# Altium schematic sheet 200_Power.SchDoc
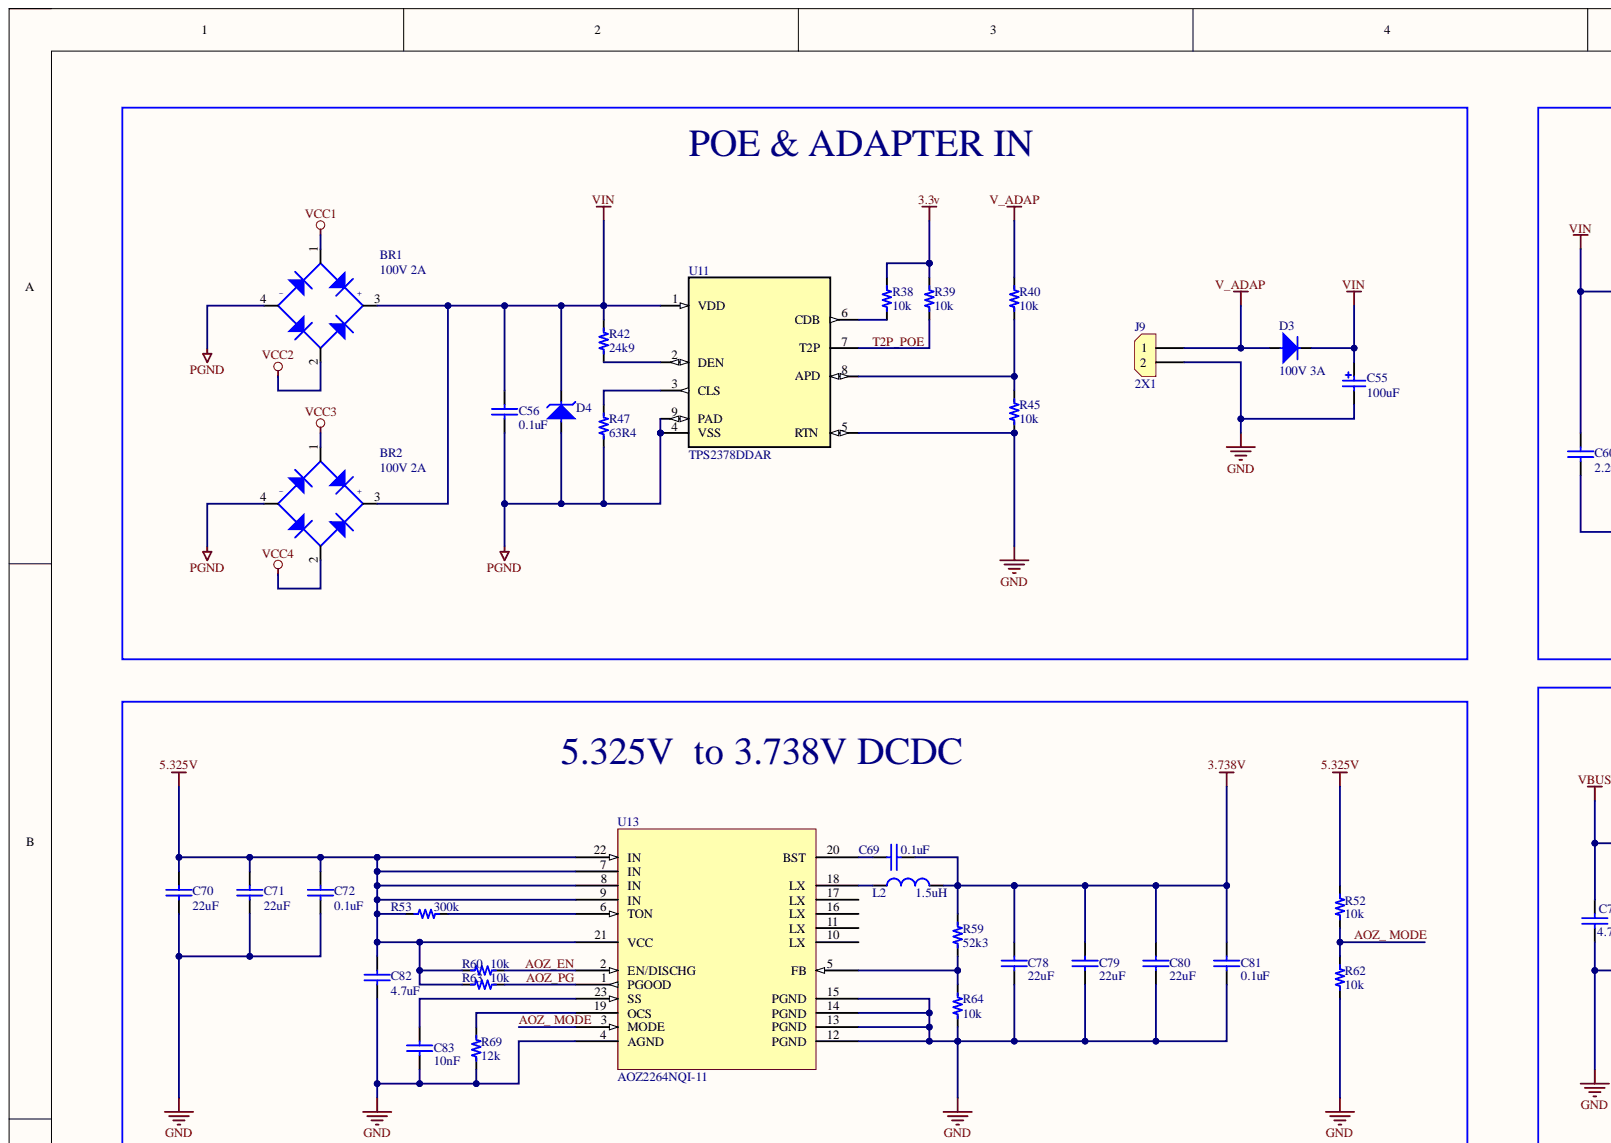
[diagram p0: 200_Power.SchDoc, top left]
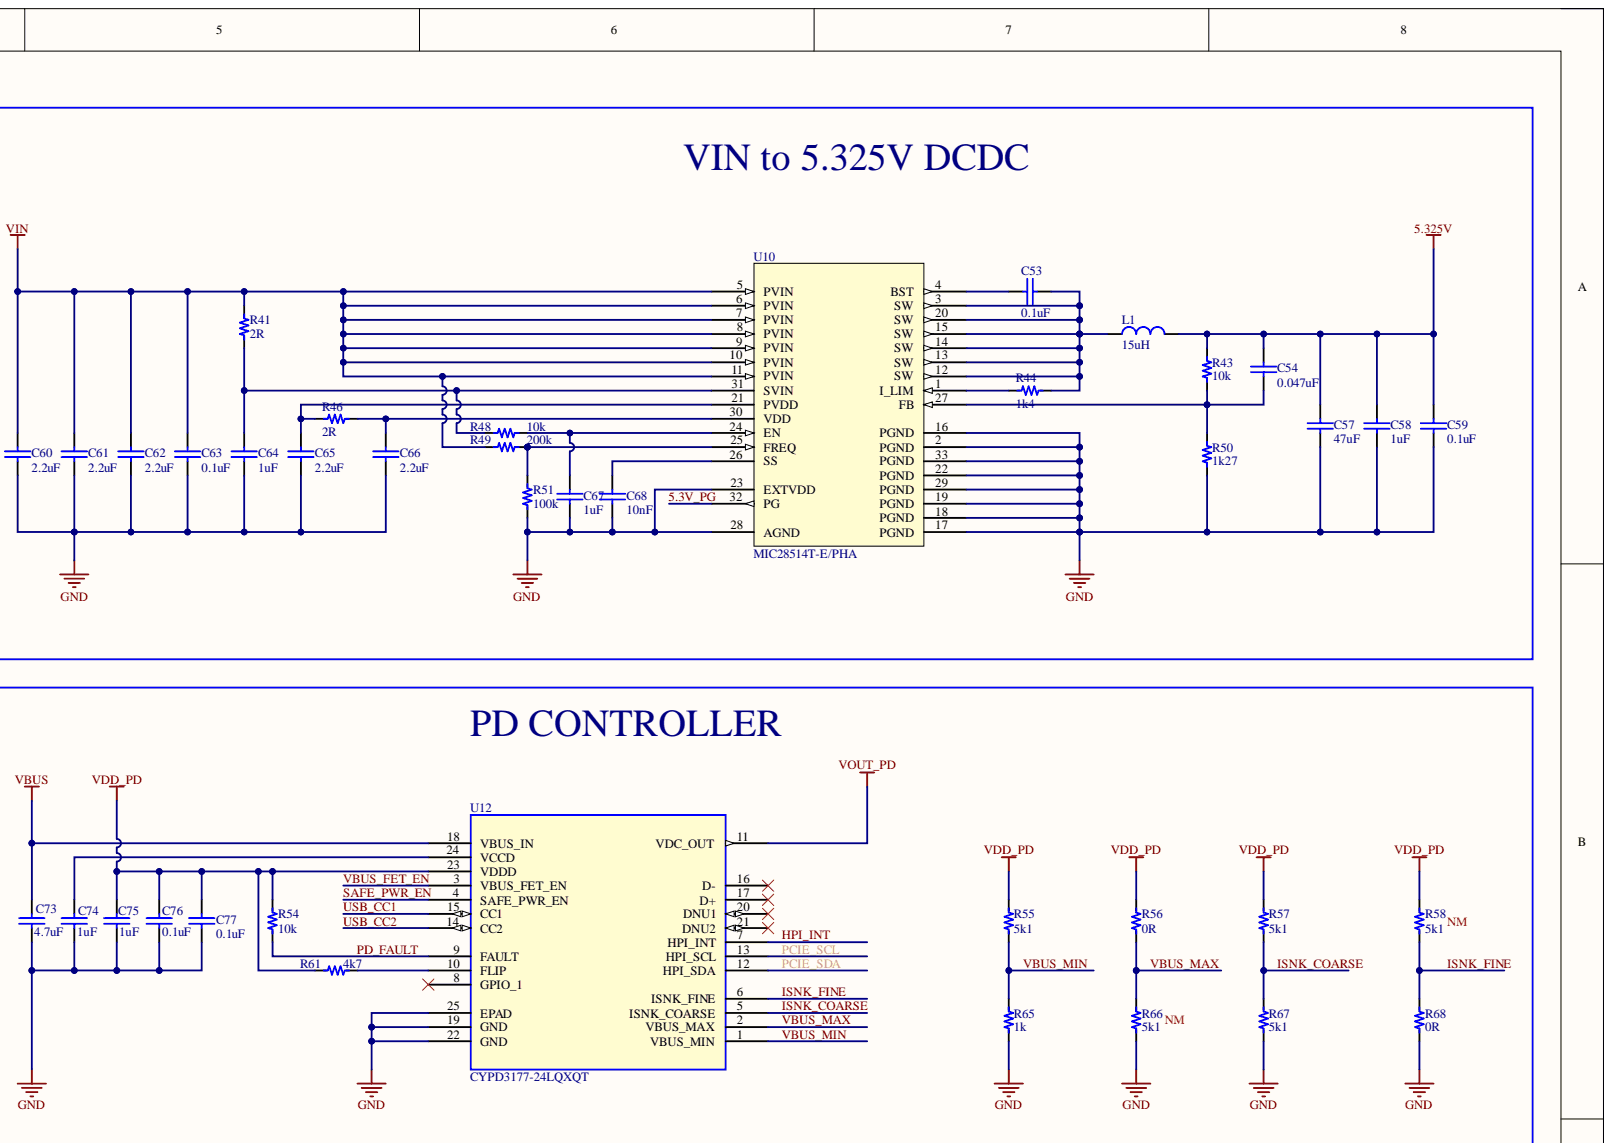
[diagram p1: 200_Power.SchDoc, top right]
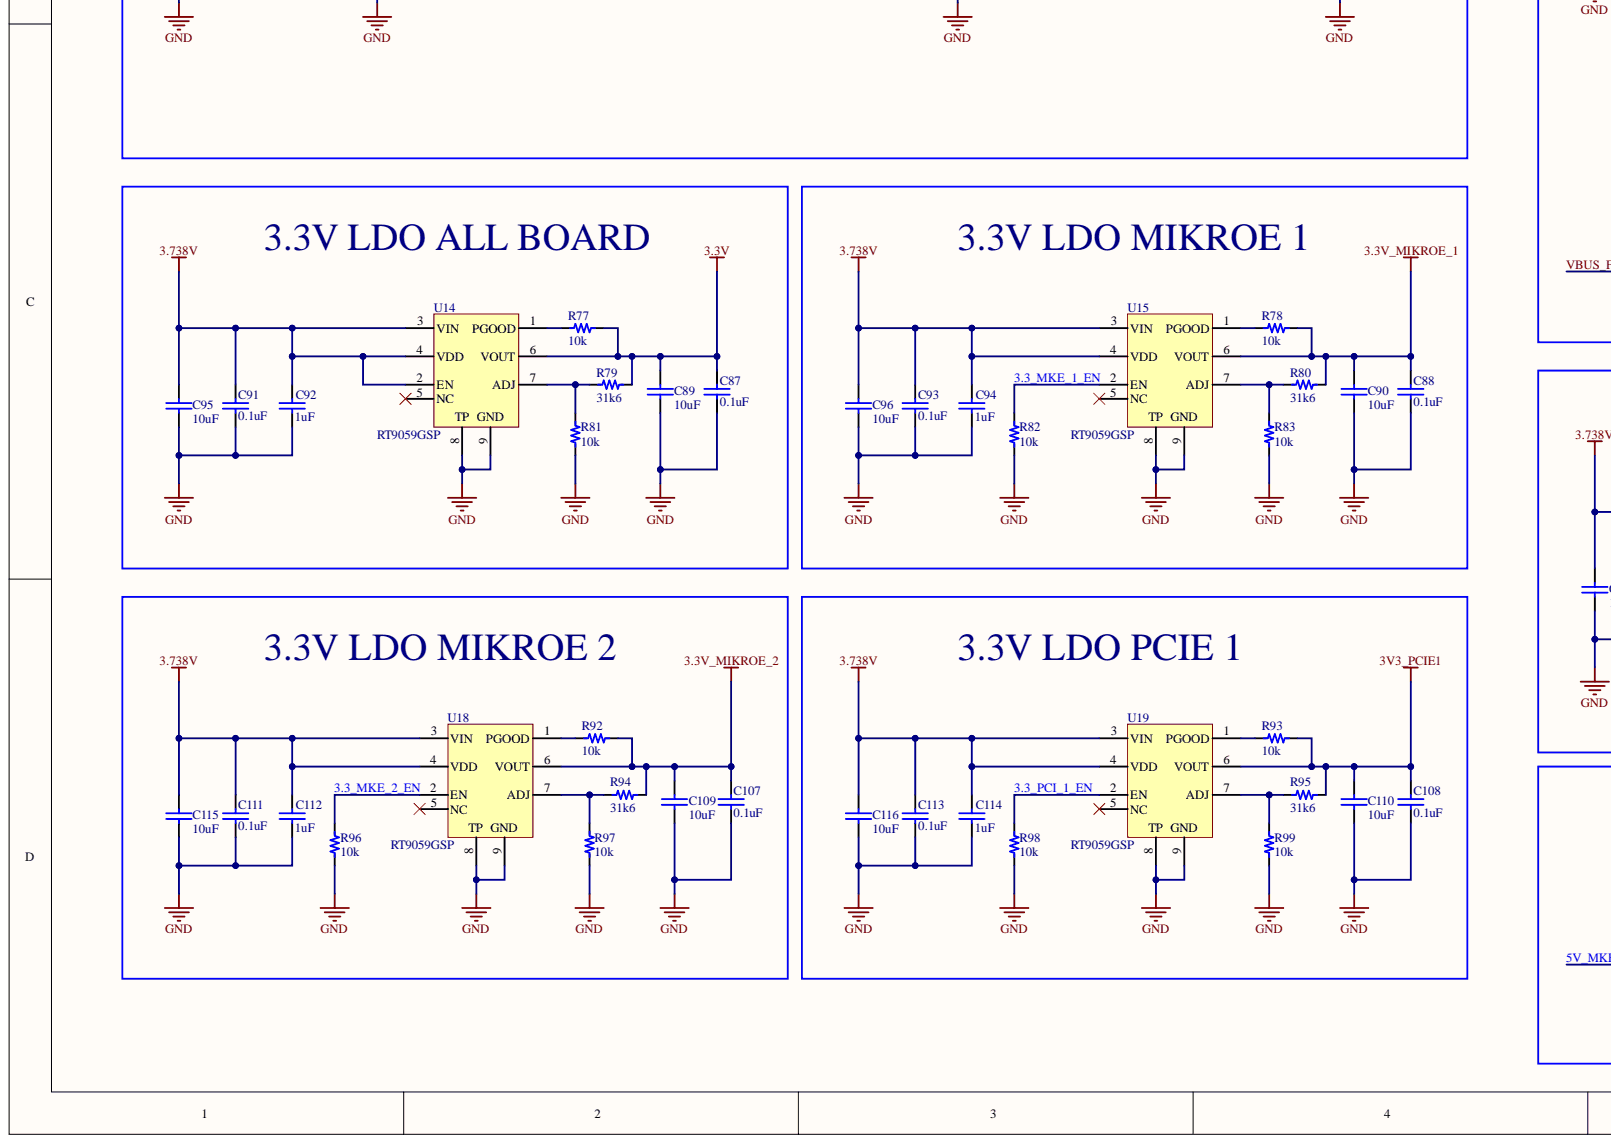
[diagram p2: 200_Power.SchDoc, bottom left]
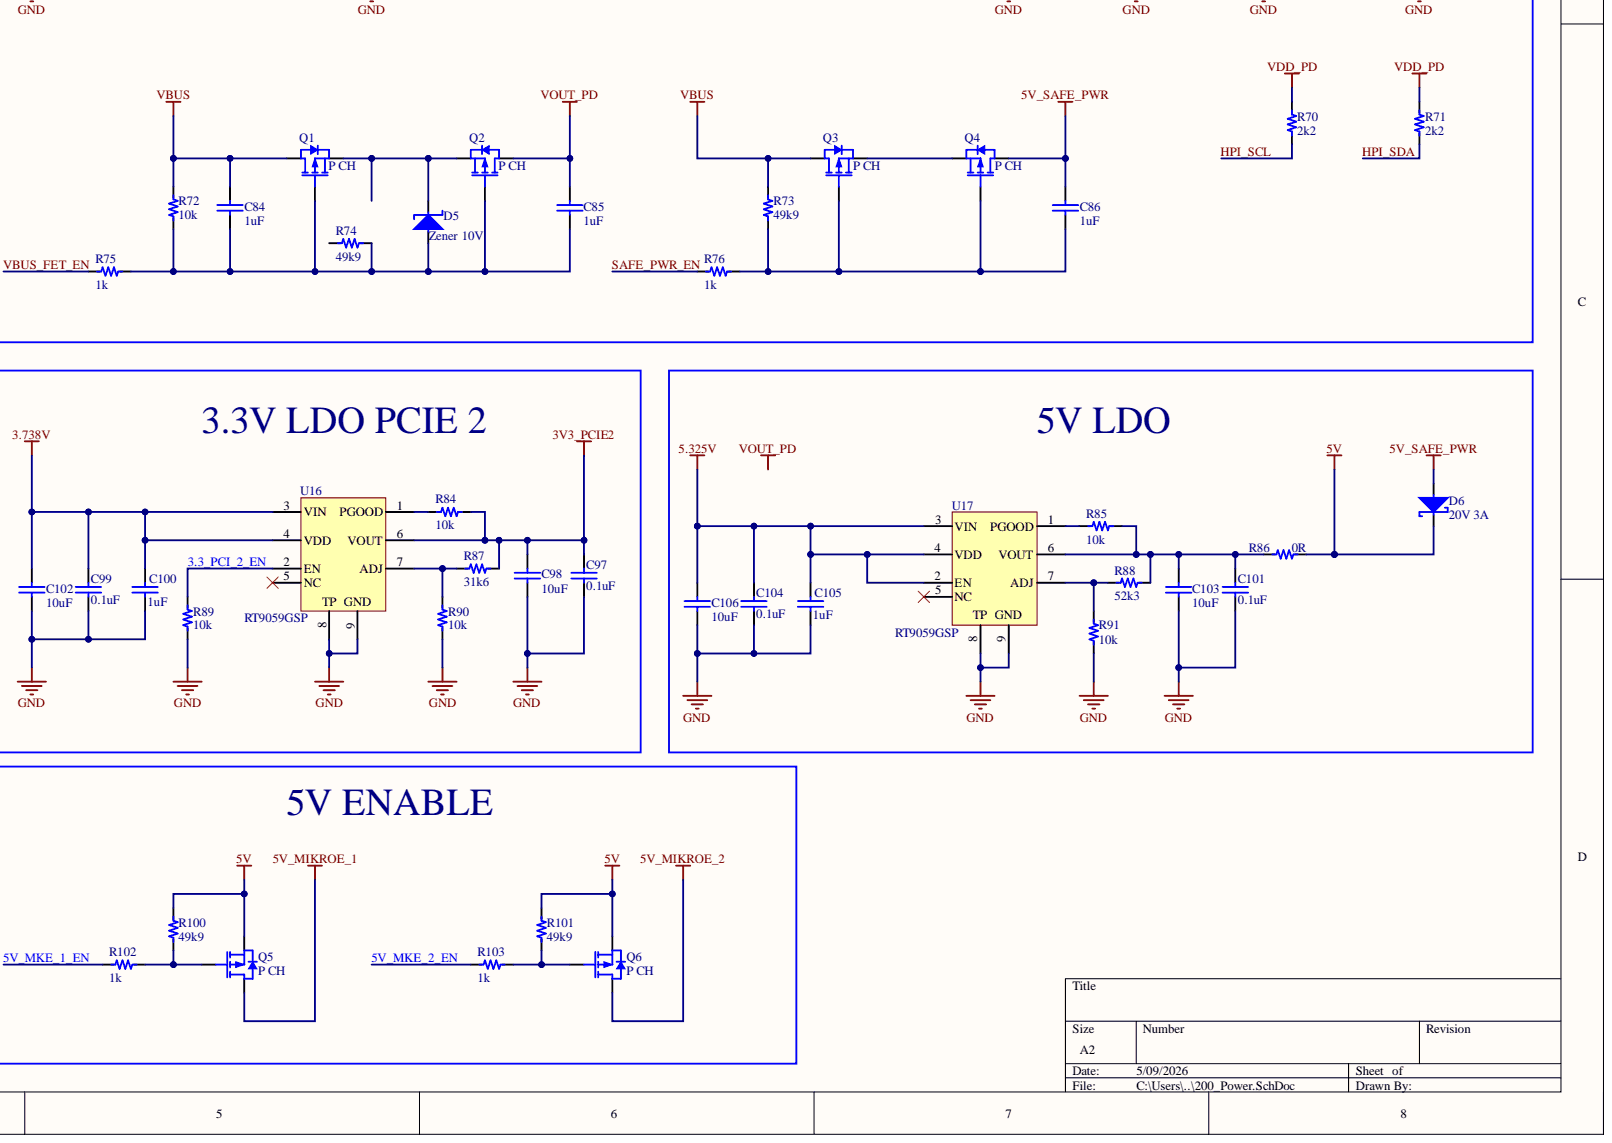
[diagram p3: 200_Power.SchDoc, bottom right]

## Parts
BR1  100V 2A  [DBS]
BR2  100V 2A  [DBS]
C53  value 0.1uF  [0603_1.4mm]  (hidden comment: 0.1uF 100V)
C54  value 0.047uF  [0603_1.4mm]  (hidden comment: 0.047uF 50V)
C55  value 100uF  [RADIAL-CAN_LSx5mm_Diax10mm_Hx14mm]  (hidden comment: 100uF 63V)
C56  value 0.1uF  [0603_1.4mm]  (hidden comment: 0.1uF 100V)
C57  value 47uF  [1206_2.8mm]  (hidden comment: 47uF 10V)
C58  value 1uF  [0603_1.4mm]  (hidden comment: 1uF 16V)
C59  value 0.1uF  [0603_1.4mm]  (hidden comment: 0.1uF 100V)
C60  value 2.2uF  [0805_1.8mm]  (hidden comment: 2.2uF 100V)
C61  value 2.2uF  [0805_1.8mm]  (hidden comment: 2.2uF 100V)
C62  value 2.2uF  [0805_1.8mm]  (hidden comment: 2.2uF 100V)
C63  value 0.1uF  [0603_1.4mm]  (hidden comment: 0.1uF 100V)
C64  value 1uF  [1206_2.8mm]  (hidden comment: 1uF 100V)
C65  value 2.2uF  [0805_1.8mm]  (hidden comment: 2.2uF 100V)
C66  value 2.2uF  [0805_1.8mm]  (hidden comment: 2.2uF 100V)
C67  value 1uF  [1206_2.8mm]  (hidden comment: 1uF 100V)
C68  value 10nF  [0603_1.4mm]  (hidden comment: 10nF 100V)
C69  value 0.1uF  [0603_1.4mm]  (hidden comment: 0.1uF 100V)
C70  value 22uF  [0603_1.4mm]  (hidden comment: 22uF 10V)
C71  value 22uF  [0603_1.4mm]  (hidden comment: 22uF 10V)
C72  value 0.1uF  [0603_1.4mm]  (hidden comment: 0.1uF 100V)
C73  value 4.7uF  [0603_1.4mm]  (hidden comment: 4.7uF 25V)
C74  value 1uF  [0603_1.4mm]  (hidden comment: 1uF 16V)
C75  value 1uF  [0603_1.4mm]  (hidden comment: 1uF 16V)
C76  value 0.1uF  [0603_1.4mm]  (hidden comment: 0.1uF 100V)
C77  value 0.1uF  [0603_1.4mm]  (hidden comment: 0.1uF 100V)
C78  value 22uF  [0603_1.4mm]  (hidden comment: 22uF 10V)
C79  value 22uF  [0603_1.4mm]  (hidden comment: 22uF 10V)
C80  value 22uF  [0603_1.4mm]  (hidden comment: 22uF 10V)
C81  value 0.1uF  [0603_1.4mm]  (hidden comment: 0.1uF 100V)
C82  value 4.7uF  [0603_1.4mm]  (hidden comment: 4.7uF 25V)
C83  value 10nF  [0603_1.4mm]  (hidden comment: 10nF 100V)
C84  value 1uF  [0603_1.4mm]  (hidden comment: 1uF 25V)
C85  value 1uF  [0603_1.4mm]  (hidden comment: 1uF 25V)
C86  value 1uF  [0603_1.4mm]  (hidden comment: 1uF 25V)
C87  value 0.1uF  [0603_1.4mm]  (hidden comment: 0.1uF 100V)
C88  value 0.1uF  [0603_1.4mm]  (hidden comment: 0.1uF 100V)
C89  value 10uF  [0805_1.8mm]  (hidden comment: 10uF 10V)
C90  value 10uF  [0805_1.8mm]  (hidden comment: 10uF 10V)
C91  value 0.1uF  [0603_1.4mm]  (hidden comment: 0.1uF 100V)
C92  value 1uF  [0603_1.4mm]  (hidden comment: 1uF 16V)
C93  value 0.1uF  [0603_1.4mm]  (hidden comment: 0.1uF 100V)
C94  value 1uF  [0603_1.4mm]  (hidden comment: 1uF 16V)
C95  value 10uF  [0805_1.8mm]  (hidden comment: 10uF 10V)
C96  value 10uF  [0805_1.8mm]  (hidden comment: 10uF 10V)
C97  value 0.1uF  [0603_1.4mm]  (hidden comment: 0.1uF 100V)
C98  value 10uF  [0805_1.8mm]  (hidden comment: 10uF 10V)
C99  value 0.1uF  [0603_1.4mm]  (hidden comment: 0.1uF 100V)
C100  value 1uF  [0603_1.4mm]  (hidden comment: 1uF 16V)
C101  value 0.1uF  [0603_1.4mm]  (hidden comment: 0.1uF 100V)
C102  value 10uF  [0805_1.8mm]  (hidden comment: 10uF 10V)
C103  value 10uF  [0805_1.8mm]  (hidden comment: 10uF 10V)
C104  value 0.1uF  [0603_1.4mm]  (hidden comment: 0.1uF 100V)
C105  value 1uF  [0603_1.4mm]  (hidden comment: 1uF 16V)
C106  value 10uF  [0805_1.8mm]  (hidden comment: 10uF 10V)
C107  value 0.1uF  [0603_1.4mm]  (hidden comment: 0.1uF 100V)
C108  value 0.1uF  [0603_1.4mm]  (hidden comment: 0.1uF 100V)
C109  value 10uF  [0805_1.8mm]  (hidden comment: 10uF 10V)
C110  value 10uF  [0805_1.8mm]  (hidden comment: 10uF 10V)
C111  value 0.1uF  [0603_1.4mm]  (hidden comment: 0.1uF 100V)
C112  value 1uF  [0603_1.4mm]  (hidden comment: 1uF 16V)
C113  value 0.1uF  [0603_1.4mm]  (hidden comment: 0.1uF 100V)
C114  value 1uF  [0603_1.4mm]  (hidden comment: 1uF 16V)
C115  value 10uF  [0805_1.8mm]  (hidden comment: 10uF 10V)
C116  value 10uF  [0805_1.8mm]  (hidden comment: 10uF 10V)
D3  100V 3A  [SMB]
D4  58V  6.4A  [DO_214AA]  (value not drawn)
D5  Zener 10V  [SOD_123]
D6  20V 3A  [DO_214AC]
J9  2X1  [CON_2X1_TH_5MM_BAT]
L1  value 15uH  [L_2SMD_13.8X12.8MM_NS]  (hidden comment: 15uH 9A)
L2  value 1.5uH  [L_2SMD_5.5X5.3MM_NS]  (hidden comment: 1.5uH 10.5A)
Q1  P CH  [SOT_23_3]
Q2  P CH  [SOT_23_3]
Q3  P CH  [SOT_23_3]
Q4  P CH  [SOT_23_3]
Q5  P CH  [SOT_23_3]
Q6  P CH  [SOT_23_3]
R38  value 10k  [0603_1.4mm]  (hidden comment: 10k 1/10W)
R39  value 10k  [0603_1.4mm]  (hidden comment: 10k 1/10W)
R40  value 10k  [0603_1.4mm]  (hidden comment: 10k 1/10W)
R41  value 2R  [0805_1.8mm]  (hidden comment: 2R 2/5W)
R42  value 24k9  [0603_1.4mm]  (hidden comment: 24.9k 1/10W)
R43  value 10k  [0603_1.4mm]  (hidden comment: 10k 1/10W)
R44  value 1k4  [0603_1.4mm]  (hidden comment: 1.4K 1/10W)
R45  value 10k  [0603_1.4mm]  (hidden comment: 10k 1/10W)
R46  value 2R  [0805_1.8mm]  (hidden comment: 2R 2/5W)
R47  value 63R4  [0603_1.4mm]  (hidden comment: 63R4 1/10W)
R48  value 10k  [0603_1.4mm]  (hidden comment: 10k 1/10W)
R49  value 200k  [0603_1.4mm]  (hidden comment: 200k 1/10W)
R50  value 1k27  [0603_1.4mm]  (hidden comment: 1k27 1/10W)
R51  value 100k  [0603_1.4mm]  (hidden comment: 100k 1/10W)
R52  value 10k  [0603_1.4mm]  (hidden comment: 10k 1/10W)
R53  value 300k  [0603_1.4mm]  (hidden comment: 300k 1/10W)
R54  value 10k  [0603_1.4mm]  (hidden comment: 10k 1/10W)
R55  value 5k1  [0603_1.4mm]  (hidden comment: 5K1 1/10W)
R56  value 0R  [0603_1.4mm]  (hidden comment: 0R 1/10W)
R57  value 5k1  [0603_1.4mm]  (hidden comment: 5K1 1/10W)
R58  value 5k1  Usage: NM  [0603_1.4mm]  (hidden comment: 5K1 1/10W)
R59  value 52k3  [0603_1.4mm]  (hidden comment: 52k3 1/10W)
R60  value 10k  [0603_1.4mm]  (hidden comment: 10k 1/10W)
R61  value 4k7  [0603_1.4mm]  (hidden comment: 4k7 1/10W)
R62  value 10k  [0603_1.4mm]  (hidden comment: 10k 1/10W)
R63  value 10k  [0603_1.4mm]  (hidden comment: 10k 1/10W)
R64  value 10k  [0603_1.4mm]  (hidden comment: 10k 1/10W)
R65  value 1k  [0603_1.4mm]  (hidden comment: 1k 1/10W)
R66  value 5k1  Usage: NM  [0603_1.4mm]  (hidden comment: 5K1 1/10W)
R67  value 5k1  [0603_1.4mm]  (hidden comment: 5K1 1/10W)
R68  value 0R  [0603_1.4mm]  (hidden comment: 0R 1/10W)
R69  value 12k  [0603_1.4mm]  (hidden comment: 12k 1/10W)
R70  value 2k2  [0402_1.0mm]  (hidden comment: 2k2 1/16W)
R71  value 2k2  [0402_1.0mm]  (hidden comment: 2k2 1/16W)
R72  value 10k  [0603_1.4mm]  (hidden comment: 10k 1/10W)
R73  value 49k9  [0603_1.4mm]  (hidden comment: 49k9 1/10W)
R74  value 49k9  [0603_1.4mm]  (hidden comment: 49k9 1/10W)
R75  value 1k  [0603_1.4mm]  (hidden comment: 1k 1/10W)
R76  value 1k  [0603_1.4mm]  (hidden comment: 1k 1/10W)
R77  value 10k  [0603_1.4mm]  (hidden comment: 10k 1/10W)
R78  value 10k  [0603_1.4mm]  (hidden comment: 10k 1/10W)
R79  value 31k6  [0603_1.4mm]  (hidden comment: 31k6 1/10W)
R80  value 31k6  [0603_1.4mm]  (hidden comment: 31k6 1/10W)
R81  value 10k  [0603_1.4mm]  (hidden comment: 10k 1/10W)
R82  value 10k  [0603_1.4mm]  (hidden comment: 10k 1/10W)
R83  value 10k  [0603_1.4mm]  (hidden comment: 10k 1/10W)
R84  value 10k  [0603_1.4mm]  (hidden comment: 10k 1/10W)
R85  value 10k  [0603_1.4mm]  (hidden comment: 10k 1/10W)
R86  value 0R  [1206_2.8mm]  (hidden comment: 0R 1/4W)
R87  value 31k6  [0603_1.4mm]  (hidden comment: 31k6 1/10W)
R88  value 52k3  [0603_1.4mm]  (hidden comment: 52k3 1/10W)
R89  value 10k  [0603_1.4mm]  (hidden comment: 10k 1/10W)
R90  value 10k  [0603_1.4mm]  (hidden comment: 10k 1/10W)
R91  value 10k  [0603_1.4mm]  (hidden comment: 10k 1/10W)
R92  value 10k  [0603_1.4mm]  (hidden comment: 10k 1/10W)
R93  value 10k  [0603_1.4mm]  (hidden comment: 10k 1/10W)
R94  value 31k6  [0603_1.4mm]  (hidden comment: 31k6 1/10W)
R95  value 31k6  [0603_1.4mm]  (hidden comment: 31k6 1/10W)
R96  value 10k  [0603_1.4mm]  (hidden comment: 10k 1/10W)
R97  value 10k  [0603_1.4mm]  (hidden comment: 10k 1/10W)
R98  value 10k  [0603_1.4mm]  (hidden comment: 10k 1/10W)
R99  value 10k  [0603_1.4mm]  (hidden comment: 10k 1/10W)
R100  value 49k9  [0603_1.4mm]  (hidden comment: 49k9 1/10W)
R101  value 49k9  [0603_1.4mm]  (hidden comment: 49k9 1/10W)
R102  value 1k  [0603_1.4mm]  (hidden comment: 1k 1/10W)
R103  value 1k  [0603_1.4mm]  (hidden comment: 1k 1/10W)
U10  MIC28514T-E/PHA  [32-VQFN (6x6)]
U11  TPS2378DDAR  [8-SO_EP]
U12  CYPD3177-24LQXQT  [QFN_24_EP_4x4MM]
U13  AOZ2264NQI-11  [QFNB_23_4*4MM]
U14  RT9059GSP  [SOIC_8_3.9mm_EP]
U15  RT9059GSP  [SOIC_8_3.9mm_EP]
U16  RT9059GSP  [SOIC_8_3.9mm_EP]
U17  RT9059GSP  [SOIC_8_3.9mm_EP]
U18  RT9059GSP  [SOIC_8_3.9mm_EP]
U19  RT9059GSP  [SOIC_8_3.9mm_EP]

## Nets
3.3v: C87.1 C89.1 R38.2 R39.2 R77.2 R79.1 U14.6(VOUT)
3.3V_MIKROE_1: C88.1 C90.1 R78.2 R80.1 U15.6(VOUT)
3.3V_MIKROE_2: C107.1 C109.1 R92.2 R94.1 U18.6(VOUT)
3.738V: C69.2 C78.2 C79.2 C80.2 C81.1 C91.1 C92.1 C93.1 C94.1 C95.1 C96.1 C99.1 C100.1 C102.1 C111.1 C112.1 C113.1 C114.1 C115.1 C116.1 L2.2 R59.2 U14.2(EN) U14.3(VIN) U14.4(VDD) U15.3(VIN) U15.4(VDD) U16.3(VIN) U16.4(VDD) U18.3(VIN) U18.4(VDD) U19.3(VIN) U19.4(VDD)
3V3_PCIE1: C108.1 C110.1 R93.2 R95.1 U19.6(VOUT)
3V3_PCIE2: C97.1 C98.1 R84.2 R87.1 U16.6(VOUT)
5.325V: C54.1 C57.1 C58.1 C59.1 C70.2 C71.2 C72.1 C82.1 C104.1 C105.1 C106.1 L1.2 R43.2 R52.1 R53.1 R60.2 R63.2 U13.7(IN) U13.8(IN) U13.9(IN) U13.21(VCC) U13.22(IN) U17.2(EN) U17.3(VIN) U17.4(VDD)
5V: D6.1 Q5.2 Q6.2 R86.2 R100.2 R101.2
5V_MIKROE_1: Q5.3
5V_MIKROE_2: Q6.3
5V_SAFE_PWR: C86.1 D6.2 Q4.3
GND: C55.2 C57.2 C58.2 C59.2 C60.2 C61.2 C62.2 C63.2 C64.2 C65.2 C66.2 C67.2 C68.2 C70.1 C71.1 C72.2 C73.2 C74.2 C75.2 C76.2 C77.2 C78.1 C79.1 C80.1 C81.2 C82.2 C83.2 C87.2 C88.2 C89.2 C90.2 C91.2 C92.2 C93.2 C94.2 C95.2 C96.2 C97.2 C98.2 C99.2 C100.2 C101.2 C102.2 C103.2 C104.2 C105.2 C106.2 C107.2 C108.2 C109.2 C110.2 C111.2 C112.2 C113.2 C114.2 C115.2 C116.2 J9.2 R45.1 R50.1 R51.1 R62.2 R64.1 R65.1 R66.1 R67.1 R68.1 R69.1 R81.1 R82.1 R83.1 R89.1 R90.1 R91.1 R96.1 R97.1 R98.1 R99.1 U10.2(PGND) U10.16(PGND) U10.17(PGND) U10.18(PGND) U10.19(PGND) U10.22(PGND) U10.23(EXTVDD) U10.28(AGND) U10.29(PGND) U10.33(PGND) U11.5(RTN) U12.19(GND) U12.22(GND) U12.25(EPAD) U13.4(AGND) U13.12(PGND) U13.13(PGND) U13.14(PGND) U13.15(PGND) U14.8(TP) U14.9 U15.8(TP) U15.9 U16.8(TP) U16.9 U17.8(TP) U17.9 U18.8(TP) U18.9 U19.8(TP) U19.9
PGND: BR1.4 BR2.4 C56.2 D4.2 R47.1 U11.4(VSS) U11.9(PAD)
V_ADAP: D3.2 J9.1 R40.2
VBUS: C73.1 C84.1 Q1.3 Q3.3 R72.1 R73.2 U12.18(VBUS_IN)
VCC1: BR1.1
VCC2: BR1.2
VCC3: BR2.1
VCC4: BR2.2
VDD_PD: C75.1 C76.1 C77.1 R54.1 R55.2 R56.2 R57.2 R58.2 R61.1 R70.2 R71.2 U12.23(VDDD)
VIN: BR1.3 BR2.3 C55.1 C56.1 C60.1 C61.1 C62.1 C63.1 D3.1 D4.1 R41.2 R42.2 R49.1 U10.5(PVIN) U10.6(PVIN) U10.7(PVIN) U10.8(PVIN) U10.9(PVIN) U10.10(PVIN) U10.11(PVIN) U11.1(VDD)
VOUT_PD: C85.1 Q2.3 U12.11(VDC_OUT)
3.3_MKE_1_EN: R82.2 U15.2(EN)
3.3_MKE_2_EN: R96.2 U18.2(EN)
3.3_PCI_1_EN: R98.2 U19.2(EN)
3.3_PCI_2_EN: R89.2 U16.2(EN)
5.3V_PG: U10.32(PG)
5V_MKE_1_EN: R102.1
5V_MKE_2_EN: R103.1
AOZ_ MODE: R52.2 R62.1 U13.3(MODE)
AOZ_EN: R60.1 U13.2(EN/DISCHG)
AOZ_PG: R63.1 U13.1(PGOOD)
HPI_INT: U12.7(HPI_INT)
HPI_SCL: R70.1
HPI_SDA: R71.1
ISNK_COARSE: R57.1 R67.2 U12.5(ISNK_COARSE)
ISNK_FINE: R58.1 R68.2 U12.6(ISNK_FINE)
PCIE_SCL: U12.13(HPI_SCL)
PCIE_SDA: U12.12(HPI_SDA)
PD_FAULT: R54.2 U12.9(FAULT)
SAFE_PWR_EN: R76.1 U12.4(SAFE_PWR_EN)
T2P_POE: R39.1 U11.7(T2P)
USB_CC1: U12.15(CC1)
USB_CC2: U12.14(CC2)
VBUS_FET_EN: R75.1 U12.3(VBUS_FET_EN)
VBUS_MAX: R56.1 R66.2 U12.2(VBUS_MAX)
VBUS_MIN: R55.1 R65.2 U12.1(VBUS_MIN)
NetC53_1: C53.1 U10.4(BST)
NetC53_2: C53.2 L1.1 R44.1 U10.3(SW) U10.12(SW) U10.13(SW) U10.14(SW) U10.15(SW) U10.20(SW)
NetC54_2: C54.2 R43.1 R50.2 U10.27(FB)
NetC64_1: C64.1 R41.1 R48.2 U10.31(SVIN)
NetC65_1: C65.1 R46.2 U10.21(PVDD)
NetC66_1: C66.1 R46.1 U10.30(VDD)
NetC67_1: C67.1 R48.1 U10.24(EN)
NetC68_1: C68.1 U10.26(SS)
NetC69_1: C69.1 U13.20(BST)
NetC74_1: C74.1 U12.24(VCCD)
NetC83_1: C83.1 U13.23(SS)
NetC84_2: C84.2 C85.2 D5.2 Q1.1 Q2.1 R72.2 R74.1 R75.2
NetC86_2: C86.2 Q3.1 Q4.1 R73.1 R76.2
NetC101_1: C101.1 C103.1 R85.2 R86.1 R88.1 U17.6(VOUT)
NetD5_1: D5.1 Q1.2 Q2.2
NetL2_1: L2.1 U13.18(LX)
NetQ3_2: Q3.2 Q4.2
NetQ5_1: Q5.1 R100.1 R102.2
NetQ6_1: Q6.1 R101.1 R103.2
NetR38_1: R38.1 U11.6(CDB)
NetR40_1: R40.1 R45.2 U11.8(APD)
NetR42_1: R42.1 U11.2(DEN)
NetR44_2: R44.2 U10.1(I_LIM)
NetR47_2: R47.2 U11.3(CLS)
NetR49_2: R49.2 R51.2 U10.25(FREQ)
NetR53_2: R53.2 U13.6(TON)
NetR59_1: R59.1 R64.2 U13.5(FB)
NetR61_2: R61.2 U12.10(FLIP)
NetR69_2: R69.2 U13.19(OCS)
NetR77_1: R77.1 U14.1(PGOOD)
NetR78_1: R78.1 U15.1(PGOOD)
NetR79_2: R79.2 R81.2 U14.7(ADJ)
NetR80_2: R80.2 R83.2 U15.7(ADJ)
NetR84_1: R84.1 U16.1(PGOOD)
NetR85_1: R85.1 U17.1(PGOOD)
NetR87_2: R87.2 R90.2 U16.7(ADJ)
NetR88_2: R88.2 R91.2 U17.7(ADJ)
NetR92_1: R92.1 U18.1(PGOOD)
NetR93_1: R93.1 U19.1(PGOOD)
NetR94_2: R94.2 R97.2 U18.7(ADJ)
NetR95_2: R95.2 R99.2 U19.7(ADJ)

Not connected: R74 2; U12 8(GPIO_1) 16(D-) 17(D+) 20(DNU1) 21(DNU2); U13 10(LX) 11(LX) 16(LX) 17(LX); U14 5(NC); U15 5(NC); U16 5(NC); U17 5(NC); U18 5(NC); U19 5(NC)

## Library data of the parts
BR1 BR2 = BR_1000V_2A: BRIDGE RECT 1PHASE 1KV 2A DB-S; Manufacturer: SMC Diode Solutions; Manufacturer Part Number: DB207S; Component Kind: Standard; ComponentLink1Description: Digi-Key; ComponentLink1URL: https://www.digikey.com/en/products/detail/smc-diode-solutions/DB207S/21705441; Last modified date: 20250717; Library Name: Discrete_semiconductors.IntLib; Supplier 1: Digi-Key; Supplier Part Number 1: 1655-DB207SCT-ND
C53 C56 C59 C63 C69 C72 C76 C77 C81 C87 C88 C91 C93 C97 C99 C101 C104 C107 C108 C111 C113 = Cap_0.1uF_0603_100V: CAP CER 0.1UF 100V X7R 0603; Manufacturer: Samsung Electro-Mechanics; Manufacturer Part Number: CL10B104KC8NNNC; Component Kind: Standard; ComponentLink1Description: Digi-Key; ComponentLink1URL: https://www.digikey.com/en/products/detail/samsung-electro-mechanics/CL10B104KC8NNNC/5961291; Last modified date: 20250321; Library Name: Capacitors.IntLib; Supplier 1: Digi-Key; Supplier Part Number 1: 1276-6807-1-ND
C54 = Cap_0.047uF_0603_50V: CAP CER 0.047UF 50V X7R 0603; Manufacturer: Samsung Electro-Mechanics; Manufacturer Part Number: CL10B473KB8NNNC; Component Kind: Standard; ComponentLink1Description: Digi-key; ComponentLink1URL: https://www.digikey.com/products/en?keywords=1276-1063-1-ND; Last modified date: 20250321; Library Name: Capacitors.IntLib; Supplier 1: Digi-Key; Supplier Part Number 1: 1276-1063-1-ND
C55 = Cap_100uF_63V_ALU_THT: CAP ALUM 100UF 20% 63V RADIAL; Manufacturer: Nichicon; Manufacturer Part Number: UVZ1J101MPD1TD; Component Kind: Standard; ComponentLink1Description: Digi-Key; ComponentLink1URL: https://www.digikey.com/product-detail/en/nichicon/UVZ1J101MPD1TD/493-13479-3-ND/4342035; Last modified date: 20250321; Library Name: Capacitors.IntLib; Supplier 1: Digi-Key; Supplier Part Number 1: 493-13479-1-ND
C57 = Cap_47uF_1206_10V: CAP CER 47UF 10V X5R 1206; Manufacturer: Murata Electronics; Manufacturer Part Number: GRM31CR61A476ME15L; Component Kind: Standard; ComponentLink1Description: Digi-Key; ComponentLink1URL: https://www.digikey.com/en/products/detail/murata-electronics/GRM31CR61A476ME15L/2334879?s=N4IgTCBcDaIOICUCyBmAjAYQQNjQQQBYB2bJAUTQFYAZEAXQF8g; Last modified date: 20250321; Library Name: Capacitors.IntLib; Supplier 1: Digi-Key; Supplier Part Number 1: 490-5528-1-ND
C58 C74 C75 C92 C94 C100 C105 C112 C114 = Cap_1uF_0603_16V: CAP CER 1UF 16V X7R 0603; Manufacturer: Samsung Electro-Mechanics; Manufacturer Part Number: CL10B105MO8NNWC; Component Kind: Standard; ComponentLink1Description: Digi-Key; ComponentLink1URL: https://www.digikey.com/product-detail/en/samsung-electro-mechanics/CL10B105MO8NNWC/1276-6524-1-ND/5961383; Last modified date: 20250321; Library Name: Capacitors.IntLib; Supplier 1: Digi-Key; Supplier Part Number 1: 1276-6524-1-ND
C60-C62 C65 C66 = Cap_2.2uF_0805_100V: CAP CER 2.2UF 100V X7T 0805; Manufacturer: Murata Electronics; Manufacturer Part Number: GRM21BD72A225ME01K; Component Kind: Standard; ComponentLink1Description: Digi-Key; ComponentLink1URL: https://www.digikey.com/en/products/detail/murata-electronics/GRM21BD72A225ME01K/15972575; Last modified date: 20250321; Library Name: Capacitors.IntLib; Supplier 1: Digi-Key; Supplier Part Number 1: 490-GRM21BD72A225ME01KCT-ND
C64 C67 = Cap_1uF_1206_100V: CAP CER 1UF 100V X7R 1206; Manufacturer: Samsung Electro-Mechanics; Manufacturer Part Number: CL31B105KCHSNNE; Component Kind: Standard; ComponentLink1Description: Digi-Key; ComponentLink1URL: https://www.digikey.com/en/products/detail/samsung-electro-mechanics/CL31B105KCHSNNE/3888405; Last modified date: 20250321; Library Name: Capacitors.IntLib; Supplier 1: Digi-Key; Supplier Part Number 1: 1276-2747-1-ND
C68 C83 = Cap_10000pF_0603_100V: CAP CER 10000PF 100V X7R 0603; Manufacturer: Walsin Technology Corporation; Manufacturer Part Number: 0603B103K101CT; Component Kind: Standard; ComponentLink1Description: Digi-Key; ComponentLink1URL: https://www.digikey.com/en/products/detail/walsin-technology-corporation/0603B103K101CT/9354880?s=N4IgTCBcDaIIxgJxgLRwMwA51pQOQBEQBdAXyA; Last modified date: 20250321; Library Name: Capacitors.IntLib; Supplier 1: Digi-Key; Supplier Part Number 1: 1292-1383-1-ND
C70 C71 C78-C80 = Cap_22uF_0603_10V: CAP CER 22UF 10V X5R 0603; Manufacturer: Murata Electronics North America; Manufacturer Part Number: GRM188R61A226ME15D; Component Kind: Standard; ComponentLink1Description: Digi-key; ComponentLink1URL: https://www.digikey.com/product-detail/en/murata-electronics-north-america/GRM188R61A226ME15D/490-10476-1-ND/5026394; Last modified date: 20250321; Library Name: Capacitors.IntLib; Supplier 1: Digi-Key; Supplier Part Number 1: 490-10476-1-ND
C73 C82 = Cap_4.7uF_0603_25V: CAP CER 4.7UF 25V X5R 0603; Manufacturer: Murata Electronics; Manufacturer Part Number: GRM188R61E475KE11D; Component Kind: Standard; ComponentLink1Description: Digi-key; ComponentLink1URL: https://www.digikey.com/en/products/detail/murata-electronics/GRM188R61E475KE11D/3900465?s=N4IgTCBcDaICwE4AMBaA7GJBmFBGFAcgCIgC6AvkA; Last modified date: 20250321; Library Name: Capacitors.IntLib; Supplier 1: Digi-Key; Supplier Part Number 1: 490-7203-1-ND
C84-C86 = Cap_1uF_0603_25V: CAP CER 1UF 25V X7R 0603; Manufacturer: Samsung Electro-Mechanics; Manufacturer Part Number: CL10B105KA8VPNC; Component Kind: Standard; ComponentLink1Description: Digi-Key; ComponentLink1URL: https://www.digikey.com/en/products/detail/samsung-electro-mechanics/CL10B105KA8VPNC/5961101?s=N4IgTCBcDaIIxgOwDYC0zl0auqByAIiALoC%2BQA; Last modified date: 20250321; Library Name: Capacitors.IntLib; Supplier 1: Digi-Key; Supplier Part Number 1: 1276-6617-1-ND
C89 C90 C95 C96 C98 C102 C103 C106 C109 C110 C115 C116 = Cap_10uF_0805_10V: CAP CER 10UF 10V X5R 0805; Manufacturer: KEMET; Manufacturer Part Number: C0805C106K8PACTU; Component Kind: Standard; ComponentLink1Description: Digi-Key; ComponentLink1URL: https://www.digikey.com/product-detail/en/kemet/C0805C106K8PACTU/399-4925-1-ND/1090920; Last modified date: 20250321; Library Name: Capacitors.IntLib; Supplier 1: Digi-Key; Supplier Part Number 1: 399-4925-1-ND
D3 = D_100V_3A_SMB: DIODE GEN PURP 100V 3A SMB; Manufacturer: Diodes Incorporated; Manufacturer Part Number: S3BB-13-F; Component Kind: Standard; ComponentLink1Description: Digi-Key; ComponentLink1URL: https://www.digikey.tw/en/products/detail/diodes-incorporated/S3BB-13-F/749982; Last modified date: 20250321; Library Name: Discrete_semiconductors.IntLib; Supplier 1: Digi-Key; Supplier Part Number 1: S3BB-FDICT-ND
D4 = TVS_58V_1CH_DO_214AA: TVS DIODE 58V 93.6V DO214AA; Manufacturer: ON Semiconductor; Manufacturer Part Number: SMAJ58A; Component Kind: Standard; ComponentLink1Description: Digi-Key; ComponentLink1URL: https://www.digikey.com/products/en?keywords=SMBJ58CAFSCT-ND; Last modified date: 20250321; Library Name: Circuit_protection.IntLib; Supplier 1: Digi-Key; Supplier Part Number 1: SMBJ58CAFSCT-ND
D5 = D_Zener_10V_0.5W_SOD_123: DIODE ZENER 10V 500MW SOD123; Manufacturer: Diodes Incorporated; Manufacturer Part Number: DDZ9697-7; Component Kind: Standard; ComponentLink1Description: Datasheet; ComponentLink1URL: https://www.digikey.tw/product-detail/en/diodes-incorporated/DDZ9697-7/DDZ9697DICT-ND/700181; Last modified date: 20250321; Library Name: Discrete_semiconductors.IntLib; Supplier 1: Digi-Key; Supplier Part Number 1: DDZ9697DICT-ND
D6 = D_Schottky_20V_3A_DO_214AC: DIODE SCHOTTKY 20V 3A SMA; Manufacturer: Diodes Incorporated; Manufacturer Part Number: B320AE-13; Component Kind: Standard; ComponentLink1Description: Digi-Key; ComponentLink1URL: https://www.digikey.com/product-detail/en/diodes-incorporated/B320AE-13/B320AE-13DICT-ND/8545849; Last modified date: 20250321; Library Name: Discrete_semiconductors.IntLib; Supplier 1: Digi-Key; Supplier Part Number 1: B320AE-13DICT-ND
J9 = Con_2x1_TH_5mm_Battery: Power Connectors ROHS; Manufacturer: Changzhou Amass Elec; Manufacturer Part Number: XT30U-M; Component Kind: Standard; ComponentLink1Description: Digi-Key; ComponentLink1URL: https://lcsc.com/product-detail/Power-Connectors_Changzhou-Amass-Elec-XT30U-M_C99101.html; Last modified date: 20250321; Library Name: Connectors.IntLib; Supplier 1: LCSC; Supplier Part Number 1: C99101
L1 = L_15uH_9A_NS: FIXED IND 15UH 9A 14.1 MOHM SMD; Manufacturer: CODACA; Manufacturer Part Number: CSBX1265-150M; Component Kind: Standard; ComponentLink1Description: Mouser; ComponentLink1URL: https://www.digikey.com/en/products/detail/codaca/CSBX1265-150M/16633542; Last modified date: 20260413; Library Name: Inductors-transformers.IntLib; Supplier 1: Digi-Key; Supplier Part Number 1: 4044-CSBX1265-150MCT-ND
L2 = L_1.5uH_10.5A_NS: FIXED IND 1.5UH 10.5A 11.2 MOHM; Manufacturer: Bourns Inc.; Manufacturer Part Number: SRP5030CA-1R5M; Component Kind: Standard; ComponentLink1Description: Digikey; ComponentLink1URL: https://www.digikey.com/en/products/detail/bourns-inc/SRP5030CA-1R5M/9351023?s=N4IgTCBcDaIMoCUAKBWADAZjQYQIIFoBGBFAWRAF0BfIA; Last modified date: 20260414; Library Name: Inductors-transformers.IntLib; Supplier 1: Digikey; Supplier Part Number 1: SRP5030CA-1R5MCT-ND
Q1-Q4 = Q_PFET_30V_4.3A_SOT23: MOSFET P-CH 30V 4.3A SOT23; Manufacturer: Alpha & Omega Semiconductor Inc.; Manufacturer Part Number: AO3407A; Component Kind: Standard; ComponentLink1Description: Digi-key; ComponentLink1URL: https://www.digikey.com/product-detail/en/alpha-omega-semiconductor-inc/AO3407A/785-1006-1-ND/1855948; Last modified date: 20250321; Library Name: Discrete_semiconductors.IntLib; Supplier 1: Digi-key; Supplier Part Number 1: 785-1006-1-ND
Q5 Q6 = Q_PFET_20V_2A_SOT_23-3L: MOSFET P-CH 20V 2A SOT23-3L; Manufacturer: Alpha & Omega Semiconductor Inc.; Manufacturer Part Number: AO3423; Component Kind: Standard; ComponentLink1Description: Digikey; ComponentLink1URL: https://www.digikey.com/en/products/detail/alpha-omega-semiconductor-inc/AO3423/1855788?s=N4IgTCBcDaIOwA4CsBaAjABjQNnSgcgCIgC6AvkA; Last modified date: 20250321; Library Name: Discrete_semiconductors.IntLib; Supplier 1: Digi-key; Supplier Part Number 1: 785-1016-1-ND
R38-R40 R43 R45 R48 R52 R54 R60 R62-R64 R72 R77 R78 R81-R85 R89-R93 R96-R99 = Res_10k_0603_1/10W: RES SMD 10K OHM 1% 1/10W 0603; Manufacturer: Samsung Electro-Mechanics; Manufacturer Part Number: RC1608F103CS; Component Kind: Standard; ComponentLink1Description: Digi-key; ComponentLink1URL: https://www.digikey.com/products/en?keywords=1276-3485-1-ND; Last modified date: 20250321; Supplier 1: Digi-Key; Supplier Part Number 1: 1276-3485-1-ND; Library Name: Resistors-potenciometers.IntLib
R41 R46 = Res_2R_0805_2/5W: RES SMD 2 OHM 1% 0.4W 0805; Manufacturer: Rohm Semiconductor; Manufacturer Part Number: ESR10EZPF2R00; Component Kind: Standard; ComponentLink1Description: Digi-Key; ComponentLink1URL: https://www.digikey.com/product-detail/en/rohm-semiconductor/ESR10EZPF2R00/RHM2.00AECT-ND/1983841; Last modified date: 20250321; Supplier 1: Digi-Key; Supplier Part Number 1: RHM2.00AECT-ND; Library Name: Resistors-potenciometers.IntLib
R42 = Res_24k9_0603_1/10W: RES 24.9K OHM 1% 1/10W 0603; Manufacturer: Stackpole Electronics Inc.; Manufacturer Part Number: RMCF0603FT24K9; Component Kind: Standard; ComponentLink1Description: Digi-Key; ComponentLink1URL: https://www.digikey.com/product-detail/en/stackpole-electronics-inc/RMCF0603FT24K9/RMCF0603FT24K9CT-ND/1943081; Last modified date: 20250321; Supplier 1: Digi-Key; Supplier Part Number 1: RMCF0603FT24K9CT-ND; Library Name: Resistors-potenciometers.IntLib
R44 = Res_1k4_0603_1/10W: RES SMD 1.4k OHM 1% 1/10W 0603; Manufacturer: Yageo; Manufacturer Part Number: RC0603FR-071K4L; Component Kind: Standard; ComponentLink1Description: Digi-Key; ComponentLink1URL: https://www.digikey.com/en/products/detail/yageo/RC0603FR-071K4L/726861?s=N4IgTCBcDaIEoGEAMA2JBmAYnAtEg7AIwDSALADIgC6AvkA; Last modified date: 20250321; Supplier 1: Digi-Key; Supplier Part Number 1: 311-1.40KHRCT-ND; Library Name: Resistors-potenciometers.IntLib
R47 = RES_63R4_0603_1/10W: RES 63.4 OHM 1% 1/10W 0603; Manufacturer: YAGEO; Manufacturer Part Number: RC0603FR-0763R4L; Component Kind: Standard; ComponentLink1Description: Digi-key; ComponentLink1URL: https://www.digikey.com/en/products/detail/yageo/RC0603FR-0763R4L/727339; Supplier 1: Digi-Key; Supplier Part Number 1: 311-63.4HRCT-ND; Library Name: Resistors-potenciometers.IntLib
R49 = Res_200k_0603_1/10W: RES 200K OHM 1% 1/10W 0603; Manufacturer: Stackpole Electronics Inc; Manufacturer Part Number: RMCF0603FT200K; Component Kind: Standard; ComponentLink1Description: Digi-Key; ComponentLink1URL: https://www.digikey.com/en/products/detail/stackpole-electronics-inc/RMCF0603FT200K/1760715; Last modified date: 20250321; Supplier 1: Digi-Key; Supplier Part Number 1: RMCF0603FT200KCT-ND; Library Name: Resistors-potenciometers.IntLib
R50 = Res_1k27_0603_1/10W: RES 1.27K OHM 1% 1/8W 0805; Manufacturer: YAGEO; Manufacturer Part Number: RC0805FR-071K27L; Component Kind: Standard; ComponentLink1Description: Digi-Key; ComponentLink1URL: https://www.digikey.com/en/products/detail/yageo/RC0805FR-071K27L/727475; Last modified date: 2026413; Supplier 1: Digi-Key; Supplier Part Number 1: 311-1.27KCRCT-ND; Library Name: Resistors-potenciometers.IntLib
R51 = Res_100k_0603_1/10W: RES 100k OHM 1% 1/10W 0603; Manufacturer: Stackpole Electronics Inc.; Manufacturer Part Number: RMCF0603FT100K; Component Kind: Standard; ComponentLink1Description: Digi-Key; ComponentLink1URL: https://www.digikey.com/product-detail/en/stackpole-electronics-inc/RMCF0603FT100K/RMCF0603FT100KCT-ND/1943118; Last modified date: 20250321; Supplier 1: Digi-Key; Supplier Part Number 1: RMCF0603FT100KCT-ND; Library Name: Resistors-potenciometers.IntLib
R53 = Res_300k_0603_1/10W: RES SMD 300K OHM 1% 1/10W 0603; Manufacturer: Bourns Inc.; Manufacturer Part Number: CR0603-FX-3003ELF; Component Kind: Standard; ComponentLink1Description: Digi-Key; ComponentLink1URL: https://www.digikey.com/product-detail/en/bourns-inc/CR0603-FX-3003ELF/CR0603-FX-3003ELFCT-ND/4247766; Last modified date: 20250321; Supplier 1: Digi-Key; Supplier Part Number 1: CR0603-FX-3003ELFCT-ND; Library Name: Resistors-potenciometers.IntLib
R55 R57 R58 R66 R67 = Res_5k1_0603_1/10W: RES SMD 5.1K OHM 1% 1/10W 0603; Manufacturer: Panasonic Electronic Components; Manufacturer Part Number: ERJ3EKF5101V; Component Kind: Standard; ComponentLink1Description: Digi-key; ComponentLink1URL: https://www.digikey.com/products/en?keywords=P5.10KHCT-ND; Last modified date: 20250321; Supplier 1: Digi-Key; Supplier Part Number 1: P5.10KHCT-ND; Library Name: Resistors-potenciometers.IntLib
R56 R68 = Res_0R_0603_1/10W: RES SMD 0 OHM JUMPER 1/10W 0603; Manufacturer: Panasonic Electronic Components; Manufacturer Part Number: ERJ-3GEY0R00V; Component Kind: Standard; ComponentLink1Description: Digi-key; ComponentLink1URL: https://www.digikey.com/products/en?keywords=P0.0GCT-ND; Last modified date: 20250321; Supplier 1: Digi-Key; Supplier Part Number 1: P0.0GCT-ND; Library Name: Resistors-potenciometers.IntLib
R59 R88 = Res_52k3_0603_1/10W: RES 52.3K OHM 1% 1/10W 0603; Manufacturer: YAGEO; Manufacturer Part Number: RC0603FR-0752K3L; Component Kind: Standard; ComponentLink1Description: Digi-Key; ComponentLink1URL: https://www.digikey.com/en/products/detail/yageo/RC0603FR-0752K3L/727290?s=N4IgTCBcDaIEoGEAMA2JBmAYnAtEg7AKxgDS6AMiALoC%2BQA; Last modified date: 20250321; Supplier 1: Digi-Key; Supplier Part Number 1: 311-52.3KHRCT-ND; Library Name: Resistors-potenciometers.IntLib
R61 = Res_4k7_0603_1/10W: RES SMD 4.7K OHM 1% 1/10W 0603; Manufacturer: Yageo; Manufacturer Part Number: RC0603FR-074K7L; Component Kind: Standard; ComponentLink1Description: Digi-Key; ComponentLink1URL: https://www.digikey.com/product-detail/en/yageo/RC0603FR-074K7L/311-4-70KHRCT-ND/730159; Last modified date: 20250321; Supplier 1: Digi-Key; Supplier Part Number 1: 311-4.70KHRCT-ND; Library Name: Resistors-potenciometers.IntLib
R65 R75 R76 R102 R103 = Res_1k_0603_1/10W: RES SMD 1K OHM 1% 1/10W 0603; Manufacturer: Yageo; Manufacturer Part Number: RC0603FR-071KL; Component Kind: Standard; ComponentLink1Description: Digi-Key; ComponentLink1URL: https://www.digikey.com/product-detail/en/yageo/RC0603FR-071KL/311-1-00KHRCT-ND/729790; Last modified date: 20250321; Supplier 1: Digi-Key; Supplier Part Number 1: 311-1.00KHRCT-ND; Library Name: Resistors-potenciometers.IntLib
R69 = Res_12k_0603_1/10W: RES SMD 12K OHM 1% 1/10W 0603; Manufacturer: Vishay Dale; Manufacturer Part Number: CRCW060312K0FKEAC; Component Kind: Standard; ComponentLink1Description: Digi-Key; ComponentLink1URL: https://www.digikey.com/product-detail/en/vishay-dale/CRCW060312K0FKEAC/541-4046-1-ND/7928729; Last modified date: 20250321; Supplier 1: Digi-Key; Supplier Part Number 1: 541-4046-1-ND; Library Name: Resistors-potenciometers.IntLib
R70 R71 = Res_2k2_0402_1/16W: RES 2.2K OHM 1% 1/16W 0402; Manufacturer: YAGEO; Manufacturer Part Number: RC0402FR-072K2L; Component Kind: Standard; ComponentLink1Description: Digi-Key; ComponentLink1URL: https://www.digikey.com/en/products/detail/yageo/RC0402FR-072K2L/726553; Last modified date: 20250321; Supplier 1: Digi-Key; Supplier Part Number 1: 311-2.20KLRCT-ND; Library Name: Resistors-potenciometers.IntLib
R73 R74 R100 R101 = Res_49k9_0603_1/10W: RES SMD 49.9K OHM 1% 1/10W 0603; Manufacturer: Samsung Electro-Mechanics; Manufacturer Part Number: RC1608F4992CS; Component Kind: Standard; ComponentLink1Description: Digi-key; ComponentLink1URL: https://www.digikey.com/product-detail/en/samsung-electro-mechanics/RC1608F4992CS/1276-4811-1-ND/3967783; Last modified date: 20250321; Supplier 1: Digi-Key; Supplier Part Number 1: 1276-4811-1-ND; Library Name: Resistors-potenciometers.IntLib
R79 R80 R87 R94 R95 = Res_31k6_0603_1/10W: RES SMD 31.6K OHM 1% 1/10W 0603; Manufacturer: Vishay Dale; Manufacturer Part Number: CRCW060331K6FKEA; Component Kind: Standard; ComponentLink1Description: Digi-Key; ComponentLink1URL: https://www.digikey.com/en/products/detail/vishay-dale/CRCW060331K6FKEA/1174837; Last modified date: 20250321; Supplier 1: Digi-Key; Supplier Part Number 1: 541-31.6KHCT-ND; Library Name: Resistors-potenciometers.IntLib
R86 = Res_0R_1206_1/4W: RES SMD 0 OHM JUMPER 1/4W 1206; Manufacturer: Yageo; Manufacturer Part Number: RC1206JR-070RL; Component Kind: Standard; ComponentLink1Description: Digi-key; ComponentLink1URL: https://www.digikey.com/products/en?keywords=311-0.0ERCT-ND; Last modified date: 20250321; Supplier 1: Digi-Key; Supplier Part Number 1: 311-0.0ERCT-ND; Library Name: Resistors-potenciometers.IntLib
U10 = DCDC_ADJ_5A_32VQFN: IC REG BUCK ADJ 5A 32VQFN; Manufacturer: Microchip Technology; Component Kind: Standard; ComponentLink1Description: Digi-Key; ComponentLink1URL: https://www.digikey.com/en/products/detail/microchip-technology/MIC28514T-E-PHA/7164766?s=N4IgTCBcDaILIEkDCYAcBWAjAFgCoFoBRAegAUAJAQRAF0BfIA; Last modified date: 20260413; Library Name: LDO-DC_DC.IntLib; Manufacturer Part Number: MIC28514T-E/PHA; Supplier 1: Digi-Key; Supplier Part Number 1: MIC28514T-E/PHACT-ND
U11 = IC_POE++_CNTL_TPS2378DDAR: IC POE CNTRL 1 CHANNEL 8SOPWR; Manufacturer: Texas Instruments; Component Kind: Standard; ComponentLink1Description: Digi-Key; ComponentLink1URL: https://www.digikey.com/en/products/detail/texas-instruments/TPS2378DDAR/3431161?s=N4IgTCBcDaICoAUDKYDMB2AHAEWwQQCUQBdAXyA; Last modified date: 20260406; Library Name: ICs.IntLib; Manufacturer Part Number: TPS2378DDAR; Supplier 1: Digi-Key; Supplier Part Number 1: 296-41726-1-ND
U12 = IC_PD_CYPD3177-24LQXQT: IC USB TYPE-C PORT CONTROL 24QFN; Manufacturer: Infineon Technologies; Component Kind: Standard; ComponentLink1Description: Digi-key; ComponentLink1URL: https://www.digikey.com/en/products/detail/infineon-technologies/CYPD3177-24LQXQT/10238323?s=N4IgTCBcDaIMIE0AKARAzARgOxYLRgBYAZARQA0SAVEAXQF8g; Library Name: ICs.IntLib; Manufacturer Part Number: CYPD3177-24LQXQT; Supplier 1: Digi-key; Supplier Part Number 1: 448-CYPD3177-24LQXQTCT-ND
U13 = DCDC_ADJ_15A_TFQFN: POWER IC EZBUCK; Manufacturer: Alpha & Omega Semiconductor Inc.; Component Kind: Standard; ComponentLink1Description: Digi-key; ComponentLink1URL: https://www.digikey.com/en/products/detail/alpha-omega-semiconductor-inc/AOZ2264NQI-11/10258098?s=N4IgTCBcDaIIYHsBeYwDYAsA7AjgSxAF0BfIA; Last modified date: 20250321; Library Name: LDO-DC_DC.IntLib; Manufacturer Part Number: AOZ2264NQI-11; Supplier 1: Digi-key; Supplier Part Number 1: 785-1902-1-ND
U14-U19 = LDO_ADJ_3A_8SOP: IC REG LINEAR 3A 8SOP; Manufacturer: Richtek USA Inc.; Component Kind: Standard; ComponentLink1Description: Digi-Key; ComponentLink1URL: https://www.digikey.com/product-detail/en/richtek-usa-inc/RT9059GSP/RT9059GSPCT-ND/6676804; Last modified date: 20250321; Library Name: LDO-DC_DC.IntLib; Manufacturer Part Number: RT9059GSP; Supplier 1: Digi-Key; Supplier Part Number 1: RT9059GSPCT-ND
